# Altium schematic sheet IVSense.SchDoc
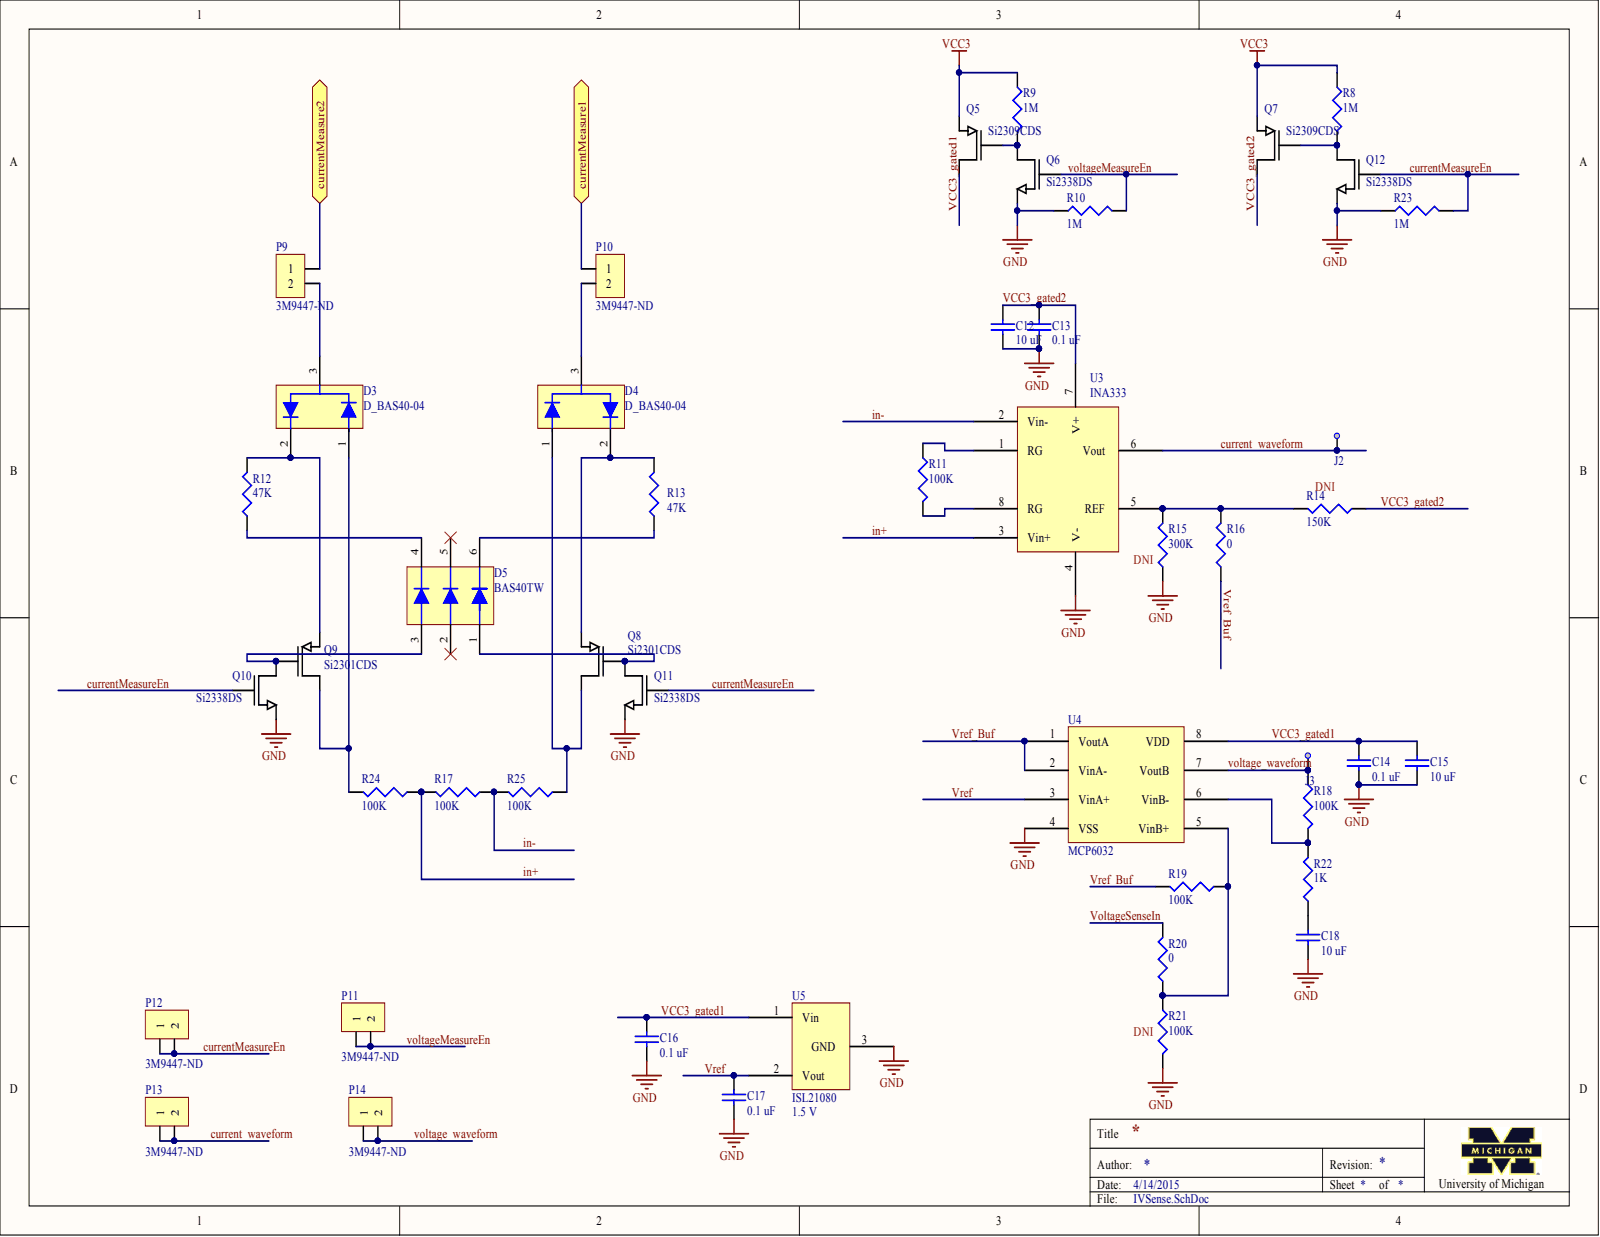
[diagram p0: IVSense.SchDoc]

## Parts
C12  value 10 uF  [0603]  (hidden comment: Ceramic capacitor)
C13  value 0.1 uF  [0603]  (hidden comment: Ceramic capacitor)
C14  value 0.1 uF  [0603]  (hidden comment: Ceramic capacitor)
C15  value 10 uF  [0603]  (hidden comment: Ceramic capacitor)
C16  value 0.1 uF  [0603]  (hidden comment: Ceramic capacitor)
C17  value 0.1 uF  [0603]  (hidden comment: Ceramic capacitor)
C18  value 10 uF  [0603]  (hidden comment: Ceramic capacitor)
D3  D_BAS40-04  [SOT23-3]
D4  D_BAS40-04  [SOT23-3]
D5  BAS40TW  [SOT-363]
J2  5004  [TestPoint]  (value not drawn)
J3  5004  [TestPoint]  (value not drawn)
P9  Supplier Part Number 1: 3M9447-ND  [1x2 header]  (hidden comment: 1 x 2 header)
P10  Supplier Part Number 1: 3M9447-ND  [1x2 header]  (hidden comment: 1 x 2 header)
P11  Supplier Part Number 1: 3M9447-ND  [1x2 header]  (hidden comment: 1 x 2 header)
P12  Supplier Part Number 1: 3M9447-ND  [1x2 header]  (hidden comment: 1 x 2 header)
P13  Supplier Part Number 1: 3M9447-ND  [1x2 header]  (hidden comment: 1 x 2 header)
P14  Supplier Part Number 1: 3M9447-ND  [1x2 header]  (hidden comment: 1 x 2 header)
Q5  Si2309CDS  [SOT23-3]
Q6  Si2338DS  [SOT23-3]
Q7  Si2309CDS  [SOT23-3]
Q8  Si2301CDS  [SOT23-3]
Q9  Si2301CDS  [SOT23-3]
Q10  Si2338DS  [SOT23-3]
Q11  Si2338DS  [SOT23-3]
Q12  Si2338DS  [SOT23-3]
R8  value 1M  [0603]  (hidden comment: Chip resistor)
R9  value 1M  [0603]  (hidden comment: Chip resistor)
R10  value 1M  [0603]  (hidden comment: Chip resistor)
R11  value 100K  [0603]  (hidden comment: Chip resistor)
R12  value 47K  [0603]  (hidden comment: Chip resistor)
R13  value 47K  [0603]  (hidden comment: Chip resistor)
R14  value 150K  [0603]  (hidden comment: Chip resistor)
R15  value 300K  [0603]  (hidden comment: Chip resistor)
R16  value 0  [0603]  (hidden comment: Chip resistor)
R17  value 100K  [0603]  (hidden comment: Chip resistor)
R18  value 100K  [0603]  (hidden comment: Chip resistor)
R19  value 100K  [0603]  (hidden comment: Chip resistor)
R20  value 0  [0603]  (hidden comment: Chip resistor)
R21  value 100K  [0603]  (hidden comment: Chip resistor)
R22  value 1K  [0603]  (hidden comment: Chip resistor)
R23  value 1M  [0603]  (hidden comment: Chip resistor)
R24  value 100K  [0603]  (hidden comment: Chip resistor)
R25  value 100K  [0603]  (hidden comment: Chip resistor)
U3  INA333  [MSOP-8]
U4  MCP6032  [MSOP-8]
U5  ISL21080  Output Voltage: 1.5 V  [SOT23-3]

## Ports
CURRENTMEASURE1, CURRENTMEASURE2

## Nets
GND: C12.2 C13.2 C14.2 C15.2 C16.2 C17.2 C18.2 Q6.2 Q10.2 Q11.2 Q12.2 R10.2 R15.2 R21.1 R23.2 U3.4(V-) U4.4(VSS) U5.3
VCC3: Q5.2 Q7.2 R8.2 R9.2
CURRENT_WAVEFORM: J2.1 P13.1 P13.2 U3.6(Vout)
CURRENTMEASUREEN: P12.1 P12.2 Q10.1 Q11.1 Q12.1 R23.1
IN+: R17.1 R24.2 U3.3(Vin+)
IN-: R17.2 R25.1 U3.2(Vin-)
VCC3_GATED1: C14.1 C15.1 C16.1 Q5.3 U4.8(VDD) U5.1
VCC3_GATED2: C12.1 C13.1 Q7.3 R14.2 U3.7(V+)
VOLTAGE_WAVEFORM: J3.1 P14.1 P14.2 R18.2 U4.7(VoutB)
VOLTAGEMEASUREEN: P11.1 P11.2 Q6.1 R10.1
VOLTAGESENSEIN: R20.1
VREF: C17.1 U4.3(VinA+) U5.2
VREF_BUF: R16.2 R19.1 U4.1(VoutA) U4.2(VinA-)
NetC18_1: C18.1 R22.2
NetD3_1: D3.1 Q9.3 R24.1
NetD3_2: D3.2 Q9.2 R12.1
NetD3_3: D3.3 P9.2
NetD4_1: D4.1 Q8.3 R25.2
NetD4_2: D4.2 Q8.2 R13.1
NetD4_3: D4.3 P10.2
NetD5_1: D5.1 Q8.1 Q11.3
NetD5_3: D5.3 Q9.1 Q10.3
NetD5_4: D5.4 R12.2
NetD5_6: D5.6 R13.2
NetP9_1: P9.1 ; port CURRENTMEASURE2
NetP10_1: P10.1 ; port CURRENTMEASURE1
NetQ5_1: Q5.1 Q6.3 R9.1
NetQ7_1: Q7.1 Q12.3 R8.1
NetR11_1: R11.1 U3.8(RG)
NetR11_2: R11.2 U3.1(RG)
NetR14_1: R14.1 R15.1 R16.1 U3.5(REF)
NetR18_1: R18.1 R22.1 U4.6(VinB-)
NetR19_2: R19.2 R20.2 R21.2 U4.5(VinB+)

Not connected: D5 2 5

## Library data of the parts
C12 C15 C18 = C_10u_6.3V_0603_ceramic: Ceramic capacitor, 10 uF, 0603 package; Manufacture: TDK; tolerance: 20%; voltage rated: 6.3V; Component Kind: Standard; Library Name: rlc.SchLib; package: 0603; Supplier 1: Digi-Key; Supplier Part Number 1: 445-4112-1-ND
C13 C14 C16 C17 = C_0.1u_25V_0603_ceramic: Ceramic capacitor, 0.1 uF, 0603 package; Manufacture: TDK; tolerance: 10%; voltage rated: 25V; Component Kind: Standard; Library Name: rlc.SchLib; package: 0603; Supplier 1: Digi-Key; Supplier Part Number 1: 445-1316-1-ND
D3 D4 = D_BAS40-04: SURFACE MOUNT SCHOTTKY BARRIER DIODE; Component Kind: Standard; Library Name: rlc.SchLib; Supplier 1: Digi-Key; Supplier Part Number 1: BAS40-04FDICT-ND
D5 = D_BAS40TW: SURFACE MOUNT SCHOTTKY BARRIER DIODE ARRAYS; Component Kind: Standard; Library Name: rlc.SchLib; Supplier 1: Digi-Key; Supplier Part Number 1: BAS40TWDICT-ND
J2 J3 = TestPoint: TEST POINT PC MINI .040"D YELLOW; Component Kind: Standard; datasheet: http://mail.keyelco.com/ENG._DEPT/PDF%20CAT%20NO%20Drawings/5004.pdf; Supplier 1: Digi-Key; Supplier Part Number 1: 5004K-ND
  J3: Library Name: connectors.SchLib
  J2: Library Name: coilcube_frontend.SchLib
P9-P14 = 1 x 2 header: 100 mils standard header; Component Kind: Standard; Library Name: connectors.SchLib; Supplier 1: Digi-Key
Q5 Q7 = Si2309CDS: P-Channel 60-V (D-S) MOSFET; Component Kind: Standard; Library Name: transistors.SchLib; Supplier 1: Digi-Key; Supplier Part Number 1: SI2309CDS-T1-E3CT-ND
Q6 Q10-Q12 = Si2338DS: N-Channel 30 V (D-S) MOSFET; Component Kind: Standard; Library Name: transistors.SchLib; Supplier 1: Digi-Key; Supplier Part Number 1: SI2338DS-T1-GE3CT-ND
Q8 Q9 = Si2301CDS: P-Channel 20 V (D-S) MOSFET; Component Kind: Standard; Library Name: transistors.SchLib; Supplier 1: Digi-Key; Supplier Part Number 1: SI2301CDS-T1-E3CT-ND
R8-R10 R23 = R_1M_0603: Chip resistor, 1 Meg, 0603 package; power: 1/10 W; tolerance: 1%; Component Kind: Standard; Library Name: rlc.SchLib; package: 0603; Supplier 1: Digi-Key; Supplier Part Number 1: 541-1.00MHCT-ND
R11 R17-R19 R21 R24 R25 = R_100K_0603: Chip resistor, 100K, 0603 package; power: 1/10 W; tolerance: 1%; Component Kind: Standard; Library Name: rlc.SchLib; package: 0603; Supplier 1: Digi-Key; Supplier Part Number 1: 541-100KHCT-ND
R12 R13 = R_47K_0603: Chip resistor, 47K, 0603 package; power: 1/10 W; tolerance: 1%; Component Kind: Standard; Library Name: rlc.SchLib; package: 0603; Supplier 1: Digi-Key; Supplier Part Number 1: 541-47.0KHCT-ND
R14 = R_150K_0603: Chip resistor, 150K, 0603 package; power: 1/10 W; tolerance: 1%; Component Kind: Standard; Library Name: rlc.SchLib; package: 0603; Supplier 1: Digi-Key; Supplier Part Number 1: 541-150KHCT-ND
R15 = R_300K_0603: Chip resistor, 300K, 0603 package; power: 1/10 W; tolerance: 1%; Component Kind: Standard; Library Name: rlc.SchLib; package: 0603; Supplier 1: Digi-Key; Supplier Part Number 1: 541-300KHCT-ND
R16 R20 = R_0_0603: Chip resistor, 0, 0603 package; Component Kind: Standard; Library Name: rlc.SchLib; package: 0603; Supplier 1: Digi-Key; Supplier Part Number 1: 541-0.0GCT-ND
R22 = R_1K_0603: Chip resistor, 1 K, 0603 package; power: 1/10 W; Temperature Coef: 100 ppm; tolerance: 1%; Component Kind: Standard; Library Name: rlc.SchLib; package: 0603; Supplier 1: Digi-Key; Supplier Part Number 1: 541-1.00KHCT-ND
U3 = INA333: Micro-Power (50µA), Zerø-Drift, Rail-to-Rail Out  Instrumentation Amplifier; Component Kind: Standard; Library Name: amplifier.SchLib; Supplier 1: Digi-Key; Supplier Part Number 1: 296-23564-1-ND
U4 = MCP6032: 0.9 µA, High Precision Op Amps; Component Kind: Standard; Library Name: amplifier.SchLib; Supplier 1: Digi-Key; Supplier Part Number 1: MCP6032T-E/MSCT-ND
U5 = ISL21080: 300nA NanoPower Voltage References; Component Kind: Standard; Library Name: reference.SchLib; Supplier 1: Digi-Key; Supplier Part Number 1: ISL21080CIH315Z-T7ACT-ND
